# Altium schematic sheet W25Q128JVSIQ.SchDoc(W25Q128JVSIQ)
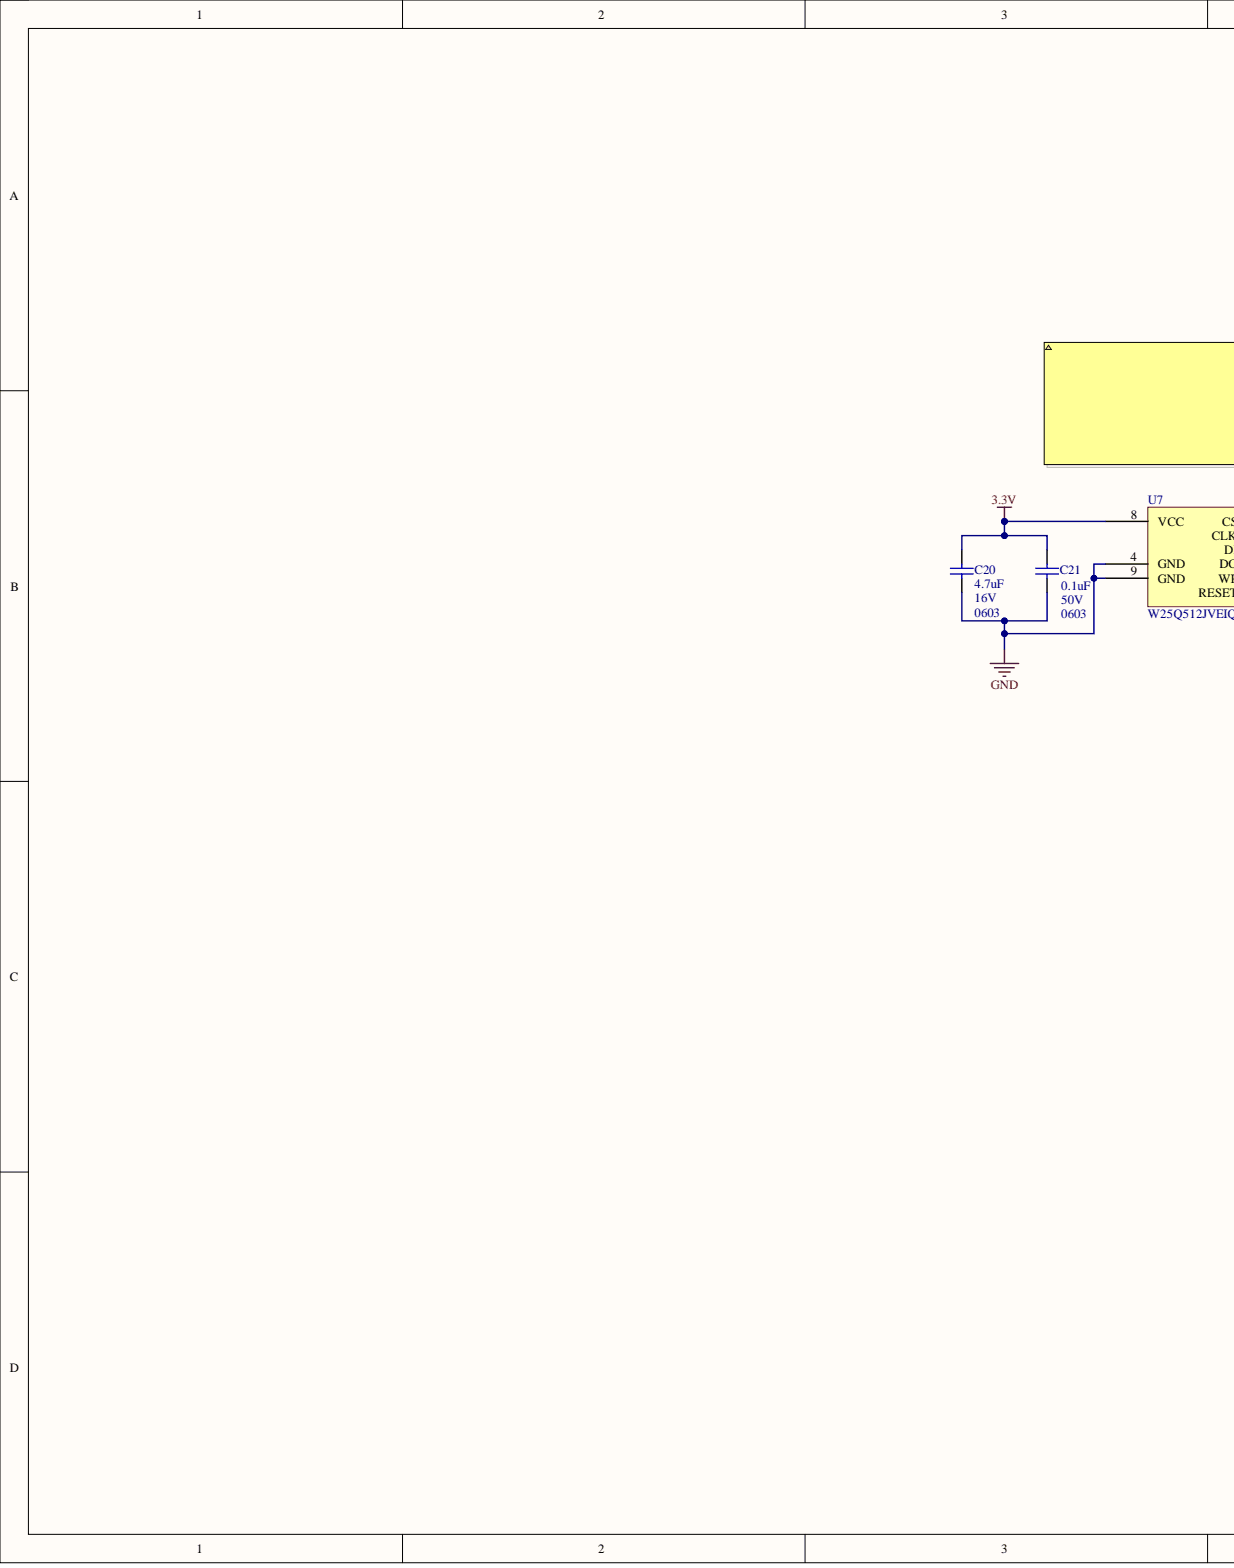
[diagram p0: W25Q128JVSIQ.SchDoc, left]
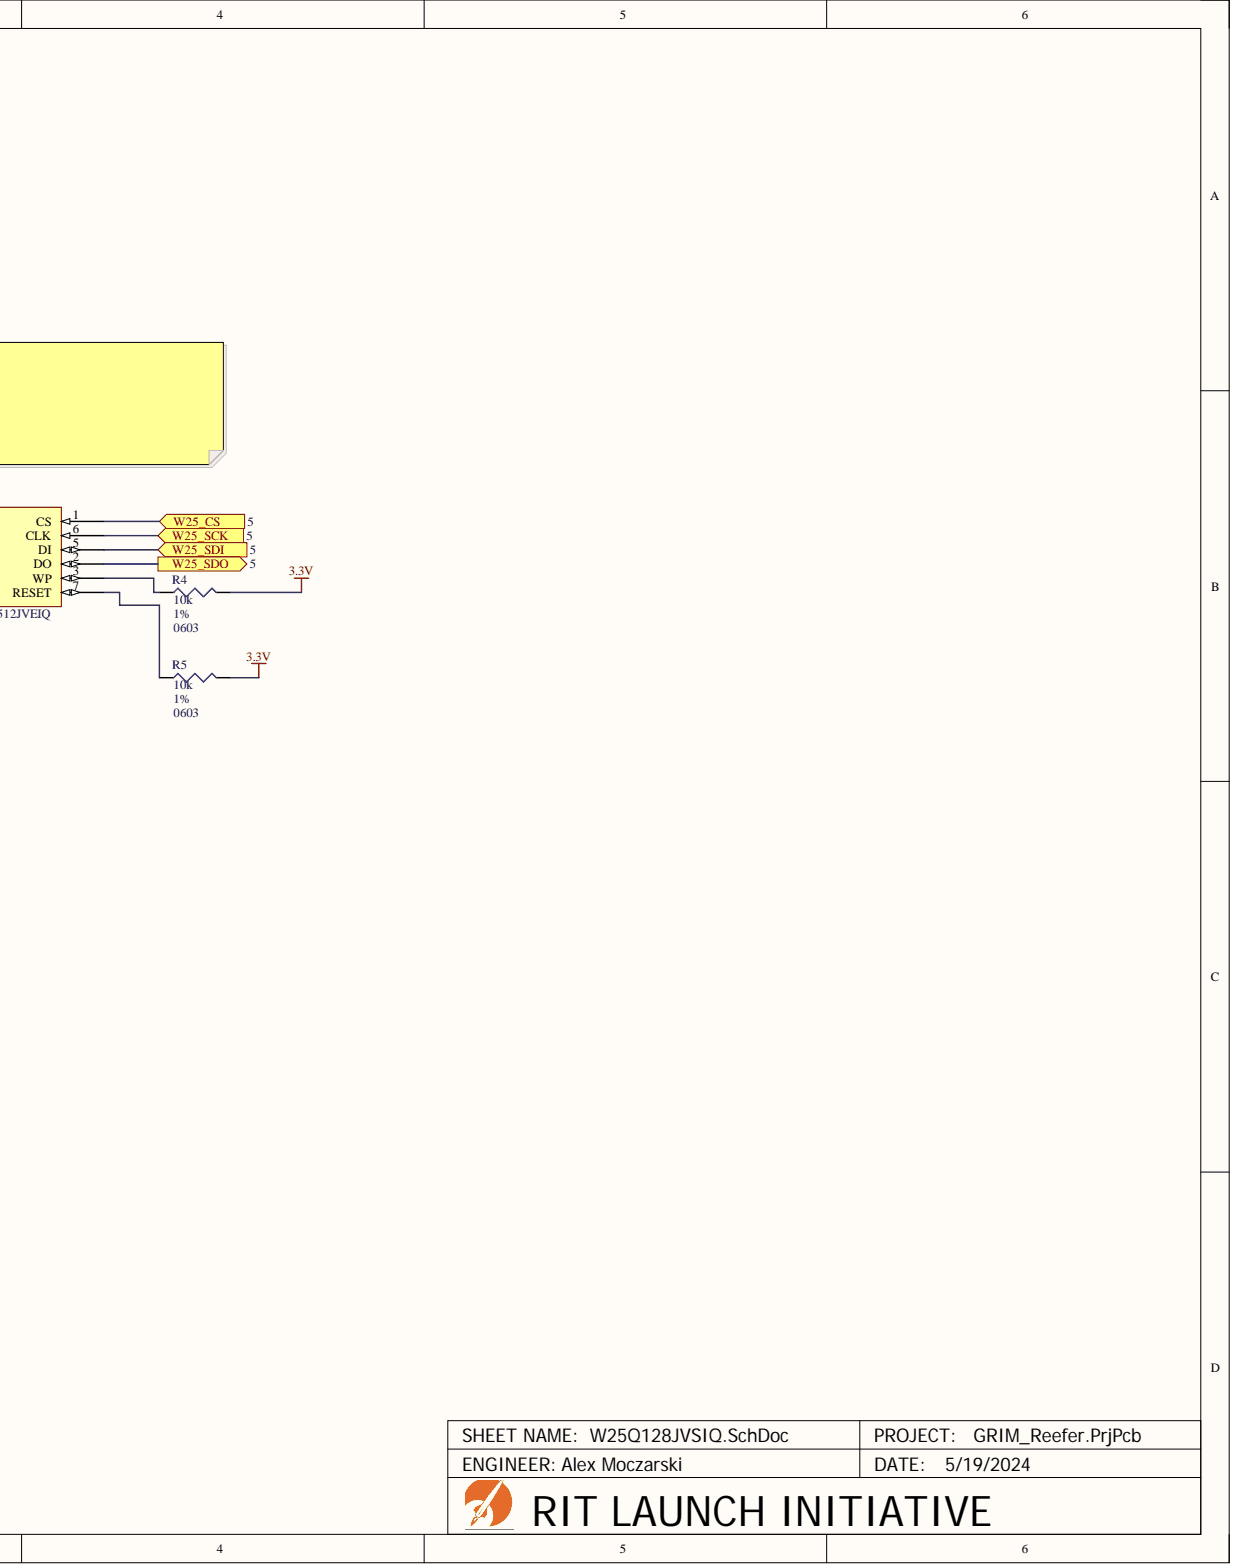
[diagram p1: W25Q128JVSIQ.SchDoc, right]

## Parts
C20  Capacitance: 4.7uF  Case Code (Imperial): 0603  Voltage: 16V  (hidden comment: CL10A475KO8NNNC)
C21  Capacitance: 0.1uF  Case Code (Imperial): 0603  Voltage: 50V  (hidden comment: CC0603KRX7R9BB104)
R4  Case/Package: 0603  Resistance: 10k  Tolerance: 1%  (hidden comment: 0603WAF1002T5E)
R5  Case/Package: 0603  Resistance: 10k  Tolerance: 1%  (hidden comment: 0603WAF1002T5E)
U7  W25Q512JVEIQ

## Ports
W25_CS, W25_SCK, W25_SDI, W25_SDO

## Nets
3.3V: C20.1 C21.2 R4.2 R5.2 U7.8(VCC)
GND: C20.2 C21.1 U7.4(GND) U7.9(GND)
W25_CS: U7.1(CS) ; port W25_CS
W25_SCK: U7.6(CLK) ; port W25_SCK
W25_SDI: U7.5(DI) ; port W25_SDI
W25_SDO: U7.2(DO) ; port W25_SDO
NetR4_1: R4.1 U7.3(WP)
NetR5_1: R5.1 U7.7(RESET)

## Library data of the parts
C20 = CMP-001-0241-2: CAP CER 4.7UF 16V X5R 0603; Material: Ceramic; Tolerance: 10%; Case Code (Metric): 1608; Case/Package: 0603; Component Kind: Standard; Construction: Flat; Contact Plating: Tin; Depth: 0.8mm; Dielectric: X5R; Height: 0.8mm; JLCPCB Part #: C19666; Length: 1.6mm; Max Operating Temperature: 85°C; Min Operating Temperature: -55°C; Mount: Surface Mount; Package Quantity: 1; Packaging: Tape and Reel; Radiation Hardening: No; RoHS Compliant: Yes; Series: CL; Thickness: 0.035inch; Voltage Rating: 16V; Width: 0.031inch; Library Name: RIT Launch Initiative
C21 = CMP-001-0242-3: CAP CER 0.1UF 50V X7R 0603; Dielectric Material: Ceramic; Tolerance: 10%; Case Code (Metric): 1608; Case/Package: 0603; Component Kind: Standard; Depth: 0.8mm; Dielectric: X7R; Halogen Free: Halogen Free; Height: 0.8mm; JLCPCB Part #: C14663; Lead Free: Lead Free; Length: 0.063inch; Max Operating Temperature: 125°C; Min Operating Temperature: -55°C; Mount: Surface Mount; Number of Pins: 2; Package Quantity: 1; Packaging: Tape and Reel; Radiation Hardening: No; REACH SVHC: No SVHC; RoHS Compliant: Yes; Series: CC; Termination: SMD/SMT; Thickness: 0.035inch; Voltage Rating: 50V; Width: 0.031inch; Library Name: RIT Launch Initiative
R4 R5 = CMP-001-0268-1: RES 10K OHM 1% 1/10W 0603; Component Kind: Standard; JLCPCB Part #: C25804; RoHS Compliant: Yes; Library Name: RIT Launch Initiative
U7 = CMP-001-0411-4: Flash, 64MX8, PDSO8; Component Kind: Standard; JLCPCB Part #: C2986165; RoHS Compliant: Yes; Library Name: RIT Launch Initiative
